# Altium schematic sheet Controller_Board_Power_Supply.SchDoc
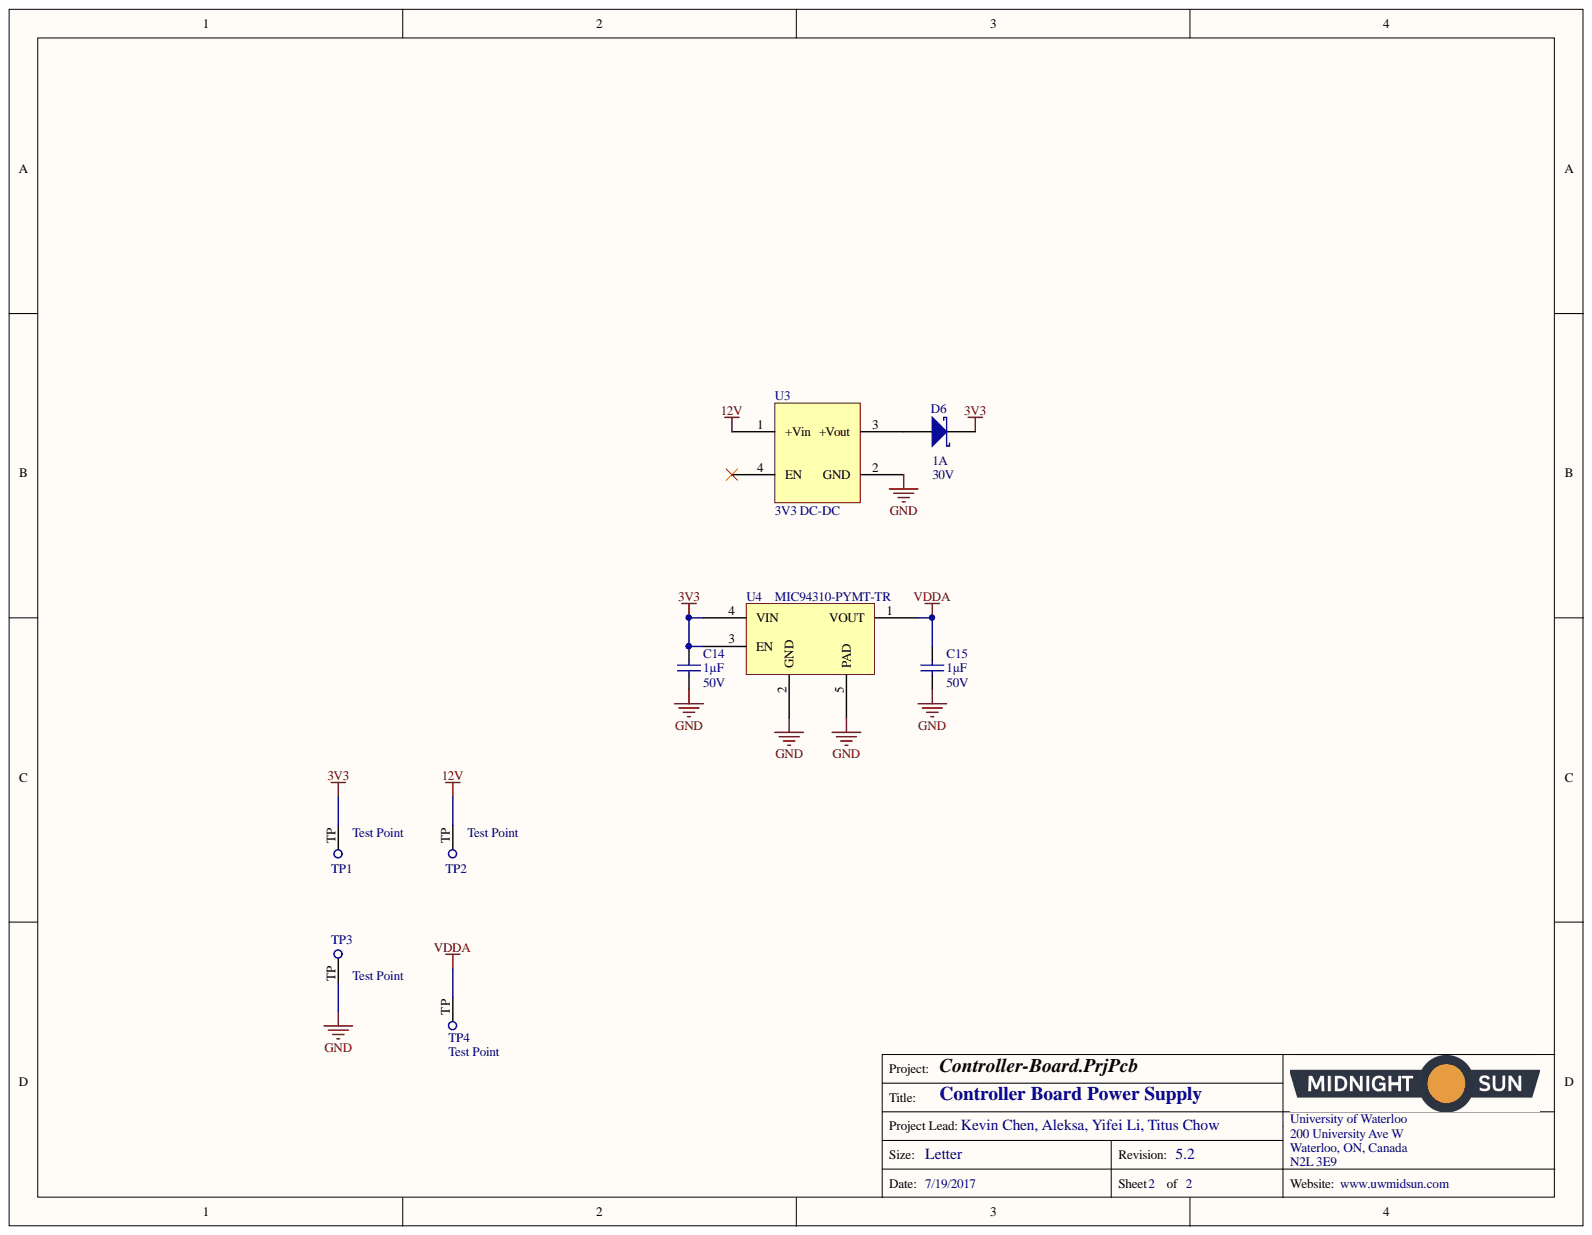
[diagram p0: Controller_Board_Power_Supply.SchDoc]

## Parts
C14  Capacitance: 1µF  Voltage: 50V  [CAP, 0603]  (hidden comment: CAP CER 1UF 50V 10% X7R 0603)
C15  Capacitance: 1µF  Voltage: 50V  [CAP, 0603]  (hidden comment: CAP CER 1UF 50V 10% X7R 0603)
D6  Current - Average Rectified (Io): 1A  Voltage - DC Reverse (Vr) (Max): 30V  [DIODE SCHOTTKY 30V 1A POWERDI123]  (hidden comment: DIODE SCHOTTKY 30V 1A POWERDI123)
TP1  Test Point  [Test Point]
TP2  Test Point  [Test Point]
TP3  Test Point  [Test Point]
TP4  Test Point  [Test Point]
U3  IC DCDC 3.3V 0.5A 4-SMD  [IC DCDC 3.3V 0.5A 4-SMD]  (value not drawn)
U4  Manufacturer Part Number: MIC94310-PYMT-TR  [IC REG LDO 3V 0.2A 4-TDFN]  (hidden comment: IC REG LDO 3V 0.2A 4-TDFN)

## Nets
3V3: C14.1 D6.2 TP1.TP U4.3(EN) U4.4(VIN)
12V: TP2.TP U3.1(+Vin)
GND: C14.2 C15.2 TP3.TP U3.2(GND) U4.2(GND) U4.5(PAD)
VDDA: C15.1 TP4.TP U4.1(VOUT)
NetD6_1: D6.1 U3.3(+Vout)

Not connected: U3 4(EN)

## Library data of the parts
C14 C15 = CAP CER 1UF 50V 10% X7R 0603: 1µF ±10% 50V Ceramic Capacitor X7R 0603 (1608 Metric); Manufacturer 1: Taiyo Yuden; Manufacturer Part Number 1: UMK107AB7105KA-T; Component Kind: Standard; ComponentLink1Description: http://www.yuden.co.jp/productdata/catalog/en/mlcc_all_e.pdf; ComponentLink1URL: http://www.yuden.co.jp/productdata/catalog/en/mlcc_all_e.pdf; Height - Seated (Max): -; Lead Spacing: -; Lead Style: -; Mounting Type: Surface Mount, MLCC; Operating Temperature: -55°C ~ 125°C; Package / Case: 0603 (1608 Metric); Packaging: Cut Tape (CT); Part Status: Active; Ratings: -; Size / Dimension: 0.063" L x 0.031" W (1.60mm x 0.80mm); Supplier 1: Digi-Key; Supplier Part Number 1: 587-3247-1-ND; Temperature Coefficient: X7R; Thickness (Max): 0.037" (0.95mm); Tolerance: ±10%; Library Name: Schematic Diagrams.SchLib
D6 = DIODE SCHOTTKY 30V 1A POWERDI123: Diode Schottky 30V 1A Surface Mount PowerDI™ 123; Manufacturer: Diodes Incorporated; Manufacturer Part Number: DFLS130L-7; Capacitance @ Vr, F: 76pF @ 10V, 1MHz; Current - Reverse Leakage @ Vr: 1mA @ 30V; Speed: Fast Recovery =< 500ns, > 200mA (Io); Voltage - Forward (Vf) (Max) @ If: 310mV @ 1A; Category: Discrete Semiconductor Products; Component Kind: Standard; ComponentLink1Description: https://www.diodes.com/assets/Datasheets/ds30492.pdf; ComponentLink1URL: https://www.diodes.com/assets/Datasheets/ds30492.pdf; Diode Type: Schottky; Mounting Type: Surface Mount; Operating Temperature - Junction: -40°C ~ 125°C; Package / Case: POWERDI®123; Packaging: Cut Tape (CT); Part Status: Active; Pricing 1: 1=0.7, 10=0.599, 100=0.447, 500=0.35126, 1000=0.27142 (CAD); RoHS: RoHS Compliant; Stock 1: 68200; Supplier 1: Digi-Key; Supplier Device Package: PowerDI™ 123; Supplier Part Number 1: DFLS130LDICT-ND; Library Name: Schematic Diagrams.SchLib
U3 = IC DCDC 3.3V 0.5A 4-SMD: Non-isolated PoL DC-DC Module 3.3V Output 0.5A 5-36V Input; Manufacturer: Recom Power; Manufacturer Part Number: ROF-78E3.3-0.5SMD-R; Current - Output (Max): 500mA; Efficiency: 84%; Number of Outputs: 1; Voltage - Input (Max): 36V; Voltage - Input (Min): 5V; Applications: ITE (Commercial); Category: Power Supplies - Board Mount; Component Kind: Standard; ComponentLink1Description: https://www.recom-power.com/pdf/Innoline/ROF-78Exx-0.5.pdf; ComponentLink1URL: https://www.recom-power.com/pdf/Innoline/ROF-78Exx-0.5.pdf; Features: SCP; Mounting Type: Surface Mount; Operating Temperature: -40°C ~ 75°C; Package / Case: 4-SMD Module; Packaging: Cut Tape (CT); Part Status: Active; Pricing 1: 1=4.97, 5=4.906, 10=4.836, 25=4.6944, 50=4.5516, 100=4.4094, 250=4.26728 (CAD); RoHS: RoHS Compliant; Size / Dimension: 0.53" L x 0.49" W x 0.18" H (13.5mm x 12.5mm x 4.5mm); Stock 1: 2290; Supplier 1: Digi-Key; Supplier Part Number 1: 945-1689-1-ND; Type: Non-Isolated PoL Module; Voltage - Isolation: -; Voltage - Output 1: 3.3V; Voltage - Output 2: -; Voltage - Output 3: -; Library Name: Schematic Diagrams.SchLib
U4 = IC REG LDO 3V 0.2A 4-TDFN: Manufacturer: Microchip Technology; Current - Limit (Min): 250mA; Current - Output: 200mA; Regulator Topology: Positive Fixed; Voltage - Dropout (Typical): 0.04V @ 200mA; Voltage - Input: Up to 3.6V; Category: Integrated Circuits (ICs); Component Kind: Standard; ComponentLink1Description: http://www.microchip.com/mymicrochip/filehandler.aspx?ddocname=en580409; ComponentLink1URL: http://www.microchip.com/mymicrochip/filehandler.aspx?ddocname=en580409; Mounting Type: Surface Mount; Operating Temperature: -40°C ~ 125°C; Package / Case: 4-UFDFN Exposed Pad; Packaging: Cut Tape (CT); Part Status: Active; Pricing 1: 1=0.45, 25=0.3708, 100=0.3386 (CAD); RoHS: RoHS Compliant; Stock 1: 4802; Supplier 1: Digi-Key; Supplier Device Package: 4-TDFN (1.2x1.6); Supplier Part Number 1: 576-4761-1-ND; Voltage - Output: 3V; Library Name: Schematic Diagrams.SchLib
TP1-TP4 = Test Point: Component Kind: Standard; Library Name: Schematic Diagrams.SchLib
